# Altium schematic sheet IOT_POW_uc.SchDoc(IOT_POW_uc)
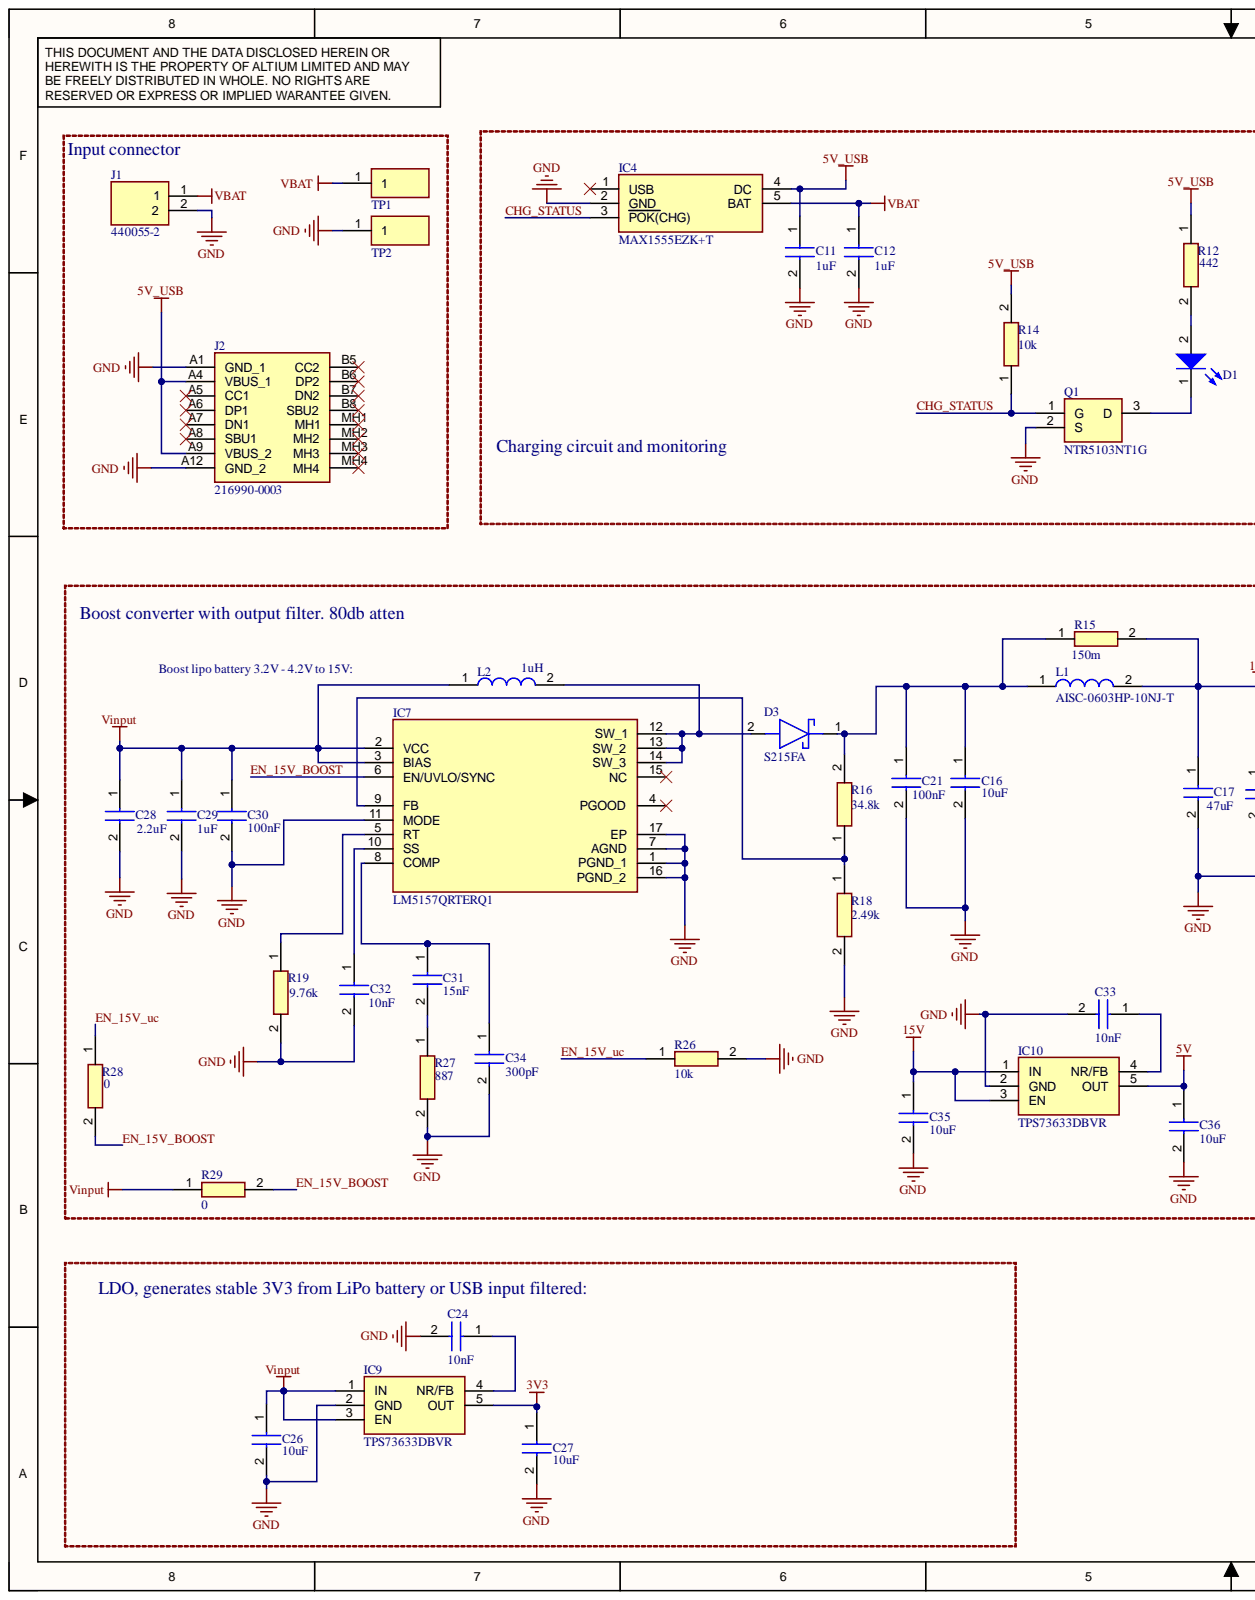
[diagram p0: IOT_POW_uc.SchDoc, left]
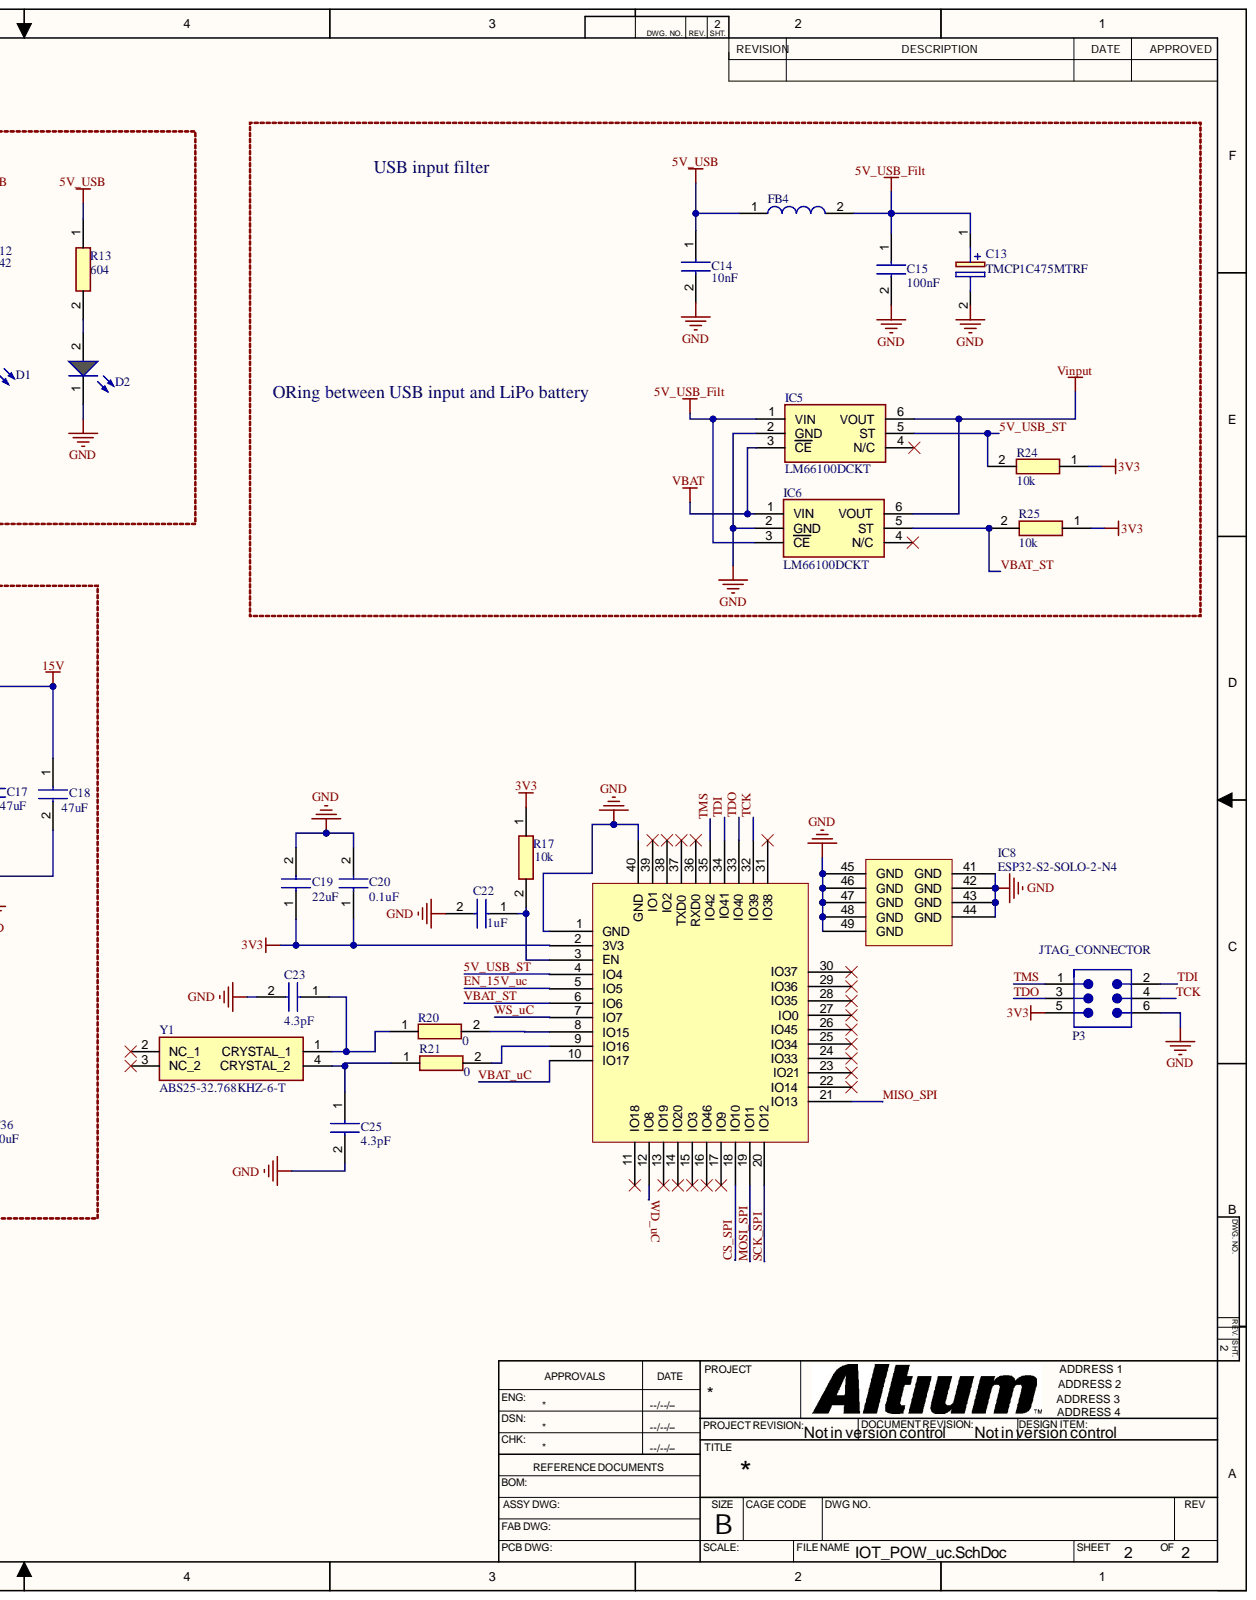
[diagram p1: IOT_POW_uc.SchDoc, right]

## Parts
C11  value 1uF  [CAP0603]  (hidden comment: CL10A105KO8NNND)
C12  value 1uF  [CAP0603]  (hidden comment: CL10A105KO8NNND)
C13  TMCP1C475MTRF  [CAPPM2012X120N]
C14  value 10nF  [CAP0603]  (hidden comment: C0603C103K4RACTU)
C15  value 100nF  [CAP0603]  (hidden comment: CL10B104KO8NNNC)
C16  value 10uF  [CAP0805]  (hidden comment: CL21A106KPFNNNF)
C17  value 47uF  [CAP0805]  (hidden comment: GRM21BR61A476ME15L)
C18  value 47uF  [CAP0805]  (hidden comment: GRM21BR61A476ME15L)
C19  value 22uF  [CAP0603]  (hidden comment: CL10A226MP8NUNE)
C20  value 0.1uF  [CAP0603]  (hidden comment: 0603YC104JAT4A)
C21  value 100nF  [CAP0603]  (hidden comment: MCASE168SB7104KTNA01)
C22  value 1uF  [CAP0603]  (hidden comment: CL10A105KO8NNND)
C23  value 4.3pF  [CAP0603]  (hidden comment: GRM1885C1H4R3CA01D)
C24  value 10nF  [CAP0603]  (hidden comment: C0603C103K4RACTU)
C25  value 4.3pF  [CAP0603]  (hidden comment: GRM1885C1H4R3CA01D)
C26  value 10uF  [CAP0805]  (hidden comment: CL21A106KPFNNNF)
C27  value 10uF  [CAP0805]  (hidden comment: CL21A106KPFNNNF)
C28  value 2.2uF  [CAP0805]  (hidden comment: CL21A225KOFNNNE)
C29  value 1uF  [CAP0603]  (hidden comment: CL10A105KO8NNND)
C30  value 100nF  [CAP0603]  (hidden comment: MCASE168SB7104KTNA01)
C31  value 15nF  [CAP0603]  (hidden comment: C0603C153G4GACTU)
C32  value 10nF  [CAP0603]  (hidden comment: C0603C103K4RACTU)
C33  value 10nF  [CAP0603]  (hidden comment: C0603C103K4RACTU)
C34  value 300pF  [CAP0603]  (hidden comment: 06033A301JAT2A)
C35  value 10uF  [CAP0805]  (hidden comment: CL21A106KPFNNNF)
C36  value 10uF  [CAP0805]  (hidden comment: CL21A106KPFNNNF)
D1  Blue, 3.2V, 140°  [WL-SMCW_0805_150080xx75000]  (value not drawn)
D2  Bright Green, 2V, 140°  [WL-SMCW_0805_150080xx75000]  (value not drawn)
D3  S215FA  [SODFL3618X143N]
FB4  BLM18PG330SN1D  [RESC0603]  (value not drawn)
IC4  MAX1555EZK+T  [SOT95P275X110-5N]
IC5  LM66100DCKT  [SOT65P210X110-6N]
IC6  LM66100DCKT  [SOT65P210X110-6N]
IC7  LM5157QRTERQ1  [QFN50P300X300X80-17N-D]
IC8  ESP32-S2-SOLO-2-N4  [ESP32-S2-SOLO-2-N4]
IC9  TPS73633DBVR  [SOT95P280X145-5N]
IC10  TPS73633DBVR  [SOT95P280X145-5N]
J1  440055-2  [SHDRRA2W50P0X200_1X2_600X770X525P]
J2  216990-0003  [2169900003]
L1  AISC-0603HP-10NJ-T  [RESC0603]
L2  value 1uH  [RESC0630]  (hidden comment: HM72L-06301R0LFTR)
P3  61300621121  [61300621121]  (value not drawn)
Q1  NTR5103NT1G  [SOT95P237X101-3N]
R12  value 442  [RESC0603]  (hidden comment: CRCW0603442RFKEA)
R13  value 604  [RESC0603]  (hidden comment: ERJ-3EKF6040V)
R14  value 10k  [RESC0603]  (hidden comment: CRCW060310K0JNEC)
R15  value 150m  [RESC0603]  (hidden comment: RL0603JR-070R15L)
R16  value 34.8k  [RESC0603]  (hidden comment: RMCF0603FT34K8)
R17  value 10k  [RESC0603]  (hidden comment: ESR03EZPJ103)
R18  value 2.49k  [RESC0603]  (hidden comment: CR0603-FX-2491ELF)
R19  value 9.76k  [RESC0603]  (hidden comment: CR0603-FX-9761ELF)
R20  value 0  [RESC0603]  (hidden comment: ERJ-3GEY0R00V)
R21  value 0  [RESC0603]  (hidden comment: ERJ-3GEY0R00V)
R24  value 10k  [RESC0603]  (hidden comment: CRCW060310K0JNEC)
R25  value 10k  [RESC0603]  (hidden comment: CRCW060310K0JNEC)
R26  value 10k  [RESC0603]  (hidden comment: CRCW060310K0JNEC)
R27  value 887  [RESC0603]  (hidden comment: RC0603FR-07887RL)
R28  value 0  [RESC0603]  (hidden comment: ERJ-3GEY0R00V)
R29  value 0  [RESC0603]  (hidden comment: ERJ-3GEY0R00V)
TP1  5006  [5006]  (value not drawn)
TP2  5006  [5006]  (value not drawn)
Y1  ABS25-32.768KHZ-6-T  [ABS2532768KHZT]

## Nets
3V3: C19.1 C20.1 C27.1 IC8.2(3V3) IC9.5(OUT) P3.5 R17.1 R24.1 R25.1
5V: C36.1 IC10.5(OUT)
5V_USB: C11.1 C14.1 FB4.1 IC4.4(DC) J2.A4(VBUS_1) J2.A9(VBUS_2) R12.1 R13.1 R14.2
5V_USB_Filt: C13.1 C15.1 FB4.2 IC5.1(VIN) IC6.3(CE)
15V: C17.1 C18.1 C35.1 IC10.1(IN) IC10.3(EN) L1.2 R15.2
GND: C11.2 C12.2 C13.2 C14.2 C15.2 C16.2 C17.2 C18.2 C19.2 C20.2 C21.2 C22.2 C23.2 C24.2 C25.2 C26.2 C27.2 C28.2 C29.2 C30.2 C32.2 C33.2 C34.2 C35.2 C36.2 D2.1 IC4.2(GND) IC5.2(GND) IC6.2(GND) IC7.1(PGND_1) IC7.7(AGND) IC7.11(MODE) IC7.16(PGND_2) IC7.17(EP) IC8.1(GND) IC8.40(GND) IC8.41(GND) IC8.42(GND) IC8.43(GND) IC8.44(GND) IC8.45 IC8.46 IC8.47 IC8.48 IC8.49 IC9.2(GND) IC10.2(GND) J1.2 J2.A1(GND_1) J2.A12(GND_2) P3.6 Q1.2 R18.2 R19.2 R26.2 R27.2 TP2.1
VBAT: C12.1 IC4.5(BAT) IC5.3(CE) IC6.1(VIN) J1.1 TP1.1
Vinput: C26.1 C28.1 C29.1 C30.1 IC5.6(VOUT) IC6.6(VOUT) IC7.2(VCC) IC7.3(BIAS) IC9.1(IN) IC9.3(EN) L2.1 R29.1
5V_USB_ST: IC5.5(ST) IC8.4(IO4) R24.2
CHG_STATUS: IC4.3(POK(CHG)) Q1.1 R14.1
CS_SPI: IC8.18(IO10)
EN_15V_BOOST: IC7.6(EN/UVLO/SYNC) R28.2 R29.2
EN_15V_uc: IC8.5(IO5) R26.1 R28.1
MISO_SPI: IC8.21(IO13)
MOSI_SPI: IC8.19(IO11)
SCK_SPI: IC8.20(IO12)
TCK: IC8.32(IO39) P3.4
TDI: IC8.34(IO41) P3.2
TDO: IC8.33(IO40) P3.3
TMS: IC8.35(IO42) P3.1
VBAT_ST: IC6.5(ST) IC8.6(IO6) R25.2
VBAT_uC: IC8.10(IO17)
WD_uC: IC8.12(IO8)
WS_uC: IC8.7(IO7)
NetC16_1: C16.1 C21.1 D3.1 L1.1 R15.1 R16.2
NetC22_1: C22.1 IC8.3(EN) R17.2
NetC23_1: C23.1 R20.1 Y1.1(CRYSTAL_1)
NetC24_1: C24.1 IC9.4(NR/FB)
NetC25_1: C25.1 R21.1 Y1.4(CRYSTAL_2)
NetC31_1: C31.1 C34.1 IC7.8(COMP)
NetC31_2: C31.2 R27.1
NetC32_1: C32.1 IC7.10(SS)
NetC33_1: C33.1 IC10.4(NR/FB)
NetD1_1: D1.1 Q1.3
NetD1_2: D1.2 R12.2
NetD2_2: D2.2 R13.2
NetD3_2: D3.2 IC7.12(SW_1) IC7.13(SW_2) IC7.14(SW_3) L2.2
NetIC7_5: IC7.5(RT) R19.1
NetIC7_9: IC7.9(FB) R16.1 R18.1
NetIC8_8: IC8.8(IO15) R20.2
NetIC8_9: IC8.9(IO16) R21.2

Not connected: IC4 1(USB); IC5 4(N/C); IC6 4(N/C); IC7 4(PGOOD) 15(NC); IC8 11(IO18) 13(IO19) 14(IO20) 15(IO3) 16(IO46) 17(IO9) 22(IO14) 23(IO21) 24(IO33) 25(IO34) 26(IO45) 27(IO0) 28(IO35) 29(IO36) 30(IO37) 31(IO38) 36(RXD0) 37(TXD0) 38(IO2) 39(IO1); J2 A5(CC1) A6(DP1) A7(DN1) A8(SBU1) B5(CC2) B6(DP2) B7(DN2) B8(SBU2) MH1 MH2 MH3 MH4; Y1 2(NC_1) 3(NC_2)

## Library data of the parts
C11 C12 C22 C29 = CL10A105KO8NNND: Multilayer Ceramic Capacitors MLCC - SMD/SMT 1uF+/-10% 16V X5R 16 0603; Manufacturer_Name: Samsung Electro-Mechanics; Case: 0603; Component Kind: Standard; Datasheet Link: https://product.tdk.com/info/en/catalog/datasheets/mlcc_commercial_midvoltage_en.pdf; Height: 0.8mm; Library Name: Library_JS.IntLib; Manufacturer_Part_Number: CL10A105KO8NNND
C13 = TMCP1C475MTRF: Tantalum Capacitors - Solid SMD 16volts 4.7uF 20% Molded Case, 0805; Manufacturer_Name: Vishay; Case: 0805; Component Kind: Standard; Datasheet Link: https://www.vishay.com/docs/40179/tmcp.pdf; Height: 1.2mm; Library Name: Library_JS.IntLib; Manufacturer_Part_Number: TMCP1C475MTRF; Value: 4.7uF
C14 C24 C32 C33 = C0603C103K4RACTU: Multilayer Ceramic Capacitors MLCC - SMD/SMT 16V 0.01uF X7R 0603 10%; Manufacturer_Name: KEMET; Case: 0603; Component Kind: Standard; Datasheet Link: https://product.tdk.com/info/en/catalog/datasheets/mlcc_commercial_midvoltage_en.pdf; Height: 0.8mm; Library Name: Library_JS.IntLib; Manufacturer_Part_Number: C0603C103K4RACTU
C15 = CL10B104KO8NNNC: Multilayer Ceramic Capacitors MLCC - SMD/SMT 100nF+/-10% 16V X7R 1608; Manufacturer_Name: Samsung Electro-Mechanics; Case: 0603; Component Kind: Standard; Datasheet Link: https://product.tdk.com/info/en/catalog/datasheets/mlcc_commercial_midvoltage_en.pdf; Height: 0.8mm; Library Name: Library_JS.IntLib; Manufacturer_Part_Number: CL10B104KO8NNNC
C16 C26 C27 C35 C36 = CL21A106KPFNNNF: Multilayer Ceramic Capacitors MLCC - SMD/SMT 10uF+/-10% 10V X5R 2 0805; Manufacturer_Name: Samsung Electro-Mechanics; Tolerance: 10%; Case: 0805; Component Kind: Standard; Datasheet Link: https://connect.kemet.com:7667/gateway/IntelliData-ComponentDocumentation/1.0/download/specsheet/C0805C104M5RACTU; Dielectric: X5R; Height: 0.88mm; Library Name: Library_JS.IntLib; Manufacturer_Part_Number: CL21A106KPFNNNF
C17 C18 = GRM21BR61A476ME15L: Multilayer Ceramic Capacitors MLCC - SMD/SMT 47 uF 10 VDC 20% 0805 X5R; Manufacturer_Name: Murata Electronics; Case: 0805; Component Kind: Standard; Datasheet Link: https://connect.kemet.com:7667/gateway/IntelliData-ComponentDocumentation/1.0/download/specsheet/C0805C104M5RACTU; Height: 0.88mm; Library Name: Library_JS.IntLib; Manufacturer_Part_Number: GRM21BR61A476ME15L
C19 = CL10A226MP8NUNE: Multilayer Ceramic Capacitors MLCC - SMD/SMT 22uF+/-20% 10V X5R 1 0603; Manufacturer_Name: Samsung Electro-Mechanics; Case: 0603; Component Kind: Standard; Height: 0.8mm; Library Name: Library_JS.IntLib; Manufacturer_Part_Number: CL10A226MP8NUNE
C20 = 0603YC104JAT4A: Multilayer Ceramic Capacitors MLCC - SMD/SMT  16V 0.1uF; Manufacturer_Name: KYOCERA AVX; Case: 0603; Component Kind: Standard; Datasheet Link: https://product.tdk.com/info/en/catalog/datasheets/mlcc_commercial_midvoltage_en.pdf; Height: 0.8mm; Library Name: Library_JS.IntLib; Manufacturer_Part_Number: 0603YC104JAT4A
C21 C30 = MCASE168SB7104KTNA01: Multilayer Ceramic Capacitors MLCC - SMD/SMT 16V 0.1uF X7R 0603 10 %; Manufacturer_Name: TAIYO YUDEN; Case: 0603; Component Kind: Standard; Datasheet Link: https://product.tdk.com/info/en/catalog/datasheets/mlcc_commercial_midvoltage_en.pdf; Height: 0.8mm; Library Name: Library_JS.IntLib; Manufacturer_Part_Number: MCASE168SB7104KTNA01
C23 C25 = GRM1885C1H4R3CA01D: Multilayer Ceramic Capacitors MLCC - SMD/SMT 4.3 pF 50 VDC 0.25 pF 0603 C0G (NP0); Manufacturer_Name: Murata Electronics; Case: 0603; Component Kind: Standard; Datasheet Link: https://product.tdk.com/info/en/catalog/datasheets/mlcc_commercial_midvoltage_en.pdf; Height: 0.8mm; Library Name: Library_JS.IntLib; Manufacturer_Part_Number: GRM1885C1H4R3CA01D
C28 = CL21A225KOFNNNE: Multilayer Ceramic Capacitors MLCC - SMD/SMT 2.2uF+/-10% 16V X5R 0805; Manufacturer_Name: Samsung Electro-Mechanics; Case: 0805; Component Kind: Standard; Datasheet Link: https://connect.kemet.com:7667/gateway/IntelliData-ComponentDocumentation/1.0/download/specsheet/C0805C104M5RACTU; Height: 0.88mm; Library Name: Library_JS.IntLib; Manufacturer_Part_Number: CL21A225KOFNNNE
C31 = C0603C153G4GACTU: Multilayer Ceramic Capacitors MLCC - SMD/SMT 16V 0.015uF C0G 0603 2%; Manufacturer_Name: KEMET; Case: 0603; Component Kind: Standard; Datasheet Link: https://product.tdk.com/info/en/catalog/datasheets/mlcc_commercial_midvoltage_en.pdf; Height: 0.8mm; Library Name: Library_JS.IntLib; Manufacturer_Part_Number: C0603C153G4GACTU
C34 = 06033A301JAT2A: Multilayer Ceramic Capacitors MLCC - SMD/SMT 25V 300pF C0G 0603 5 % Tol; Manufacturer_Name: KYOCERA AVX; Case: 0603; Component Kind: Standard; Datasheet Link: https://product.tdk.com/info/en/catalog/datasheets/mlcc_commercial_midvoltage_en.pdf; Height: 0.8mm; Library Name: Library_JS.IntLib; Manufacturer_Part_Number: 06033A301JAT2A
D1 = 150080BS75000: WL-SMCW Mono-color Chip LED Waterclear, size 0805, Blue, 3.2V, 140°; Manufacturer: Wurth Elektronik; Case/Size Code: 0805; Chip Technology: InGaN; Dominant Wavelength: 470nm; Luminous Intensity: 145mcd; Peak Wavelength: 465nm; Category: Signal LEDs; Component Kind: Standard; ComponentLink1Description: Website Link; ComponentLink1URL: https://www.we-online.com/redexpert/article/150080BS75000?ad; ComponentLink2Description: Datasheet Link; ComponentLink2URL: https://www.we-online.com/redexpert/spec/150080BS75000?ad; Emitting Color: Blue; Forward Voltage: 3.2V; Height: 0.7mm; Length: 2mm; Library Name: Altium_WL-SMCW (rev22d).IntLib; Manufacturer Part Number: 150080BS75000; Match Code: WL-SMCW; Mount: Surface Mount; Operating Temperature Max: 85°C; Operating Temperature Min: -40°C; Packaging: Tape and Reel; Simulation: 0805_150080BS75000; Viewing Angle: 140°; Width: 1.25mm
D2 = 150080VS75000: WL-SMCW Mono-color Chip LED Waterclear, size 0805, Bright Green, 2V, 140°; Manufacturer: Wurth Elektronik; Case/Size Code: 0805; Chip Technology: AlInGaP; Dominant Wavelength: 570nm; Luminous Intensity: 40mcd; Peak Wavelength: 572nm; Category: Signal LEDs; Component Kind: Standard; ComponentLink1Description: Website Link; ComponentLink1URL: https://www.we-online.com/redexpert/article/150080VS75000?ad; ComponentLink2Description: Datasheet Link; ComponentLink2URL: https://www.we-online.com/redexpert/spec/150080VS75000?ad; Emitting Color: Bright Green; Forward Voltage: 2V; Height: 0.7mm; Length: 2mm; Library Name: Altium_WL-SMCW (rev22d).IntLib; Manufacturer Part Number: 150080VS75000; Match Code: WL-SMCW; Mount: Surface Mount; Operating Temperature Max: 85°C; Operating Temperature Min: -40°C; Packaging: Tape and Reel; Simulation: 0805_150080VS75000; Viewing Angle: 140°; Width: 1.25mm
D3 = S215FA: Schottky Diodes & Rectifiers 150V 2A Schottky Barrier Rectifier; Manufacturer_Name: onsemi; Arrow Part Number: S215FA; Arrow Price/Stock: https://www.arrow.com/en/products/s215fa/on-semiconductor; Component Kind: Standard; Datasheet Link: https://componentsearchengine.com/Datasheets/2/S215FA.pdf; Height: 1.43mm; Library Name: Library_JS.IntLib; Manufacturer_Part_Number: S215FA; Mouser Part Number: 512-S215FA; Mouser Price/Stock: https://www.mouser.com/Search/Refine.aspx?Keyword=512-S215FA
FB4 = BLM18PG330SN1D: Ferrite Beads 33 OHM 25% 3A; Manufacturer_Name: Murata Electronics; Value: 33Ohm; Case: 0603; Component Kind: Standard; Datasheet Link: http://www.bourns.com/docs/Product-Datasheets/CE201210.pdf; Height: 1.03mm; Library Name: Library_JS.IntLib; Manufacturer_Part_Number: BLM18PG330SN1D
IC4 = MAX1555EZK+T: Battery Management SOT23, Dual-Input, USB/AC Adapter, 1-Cel; Manufacturer_Name: Analog Devices; Component Kind: Standard; Datasheet Link: http://datasheets.maximintegrated.com/en/ds/MAX1551-MAX1555.pdf; Height: 1.1mm; Library Name: Library_JS.IntLib; Manufacturer_Part_Number: MAX1555EZK+T
IC5 IC6 = LM66100DCKT: Hot Swap Voltage Controllers 1.5-V to 5.5-V, 1.5-A, 0.5-uA IQ ideal diode with integrated FET 6-SC70 -40 to 10; Manufacturer_Name: Texas Instruments; Component Kind: Standard; Datasheet Link: http://www.ti.com/general/docs/suppproductinfo.tsp?distId=10&gotoUrl=http%3A%2F%2Fwww.ti.com%2Flit%2Fgpn%2flm66100; Height: 1.1mm; Library Name: Library_JS.IntLib; Manufacturer_Part_Number: LM66100DCKT
IC7 = LM5157QRTERQ1: Switching Voltage Regulators 6-A, 50-V, 2.2-MHz wide VIN boost, flyback, & SEPIC converter with dual random spread spectrum 16-WQFN -40 to 150; Manufacturer_Name: Texas Instruments; Case: WQFN-16; Component Kind: Standard; Datasheet Link: https://pdf1.alldatasheet.com/datasheet-pdf/view/1264204/TI/LM5157QRTERQ1.html; Height: 0.8mm; Library Name: Library_JS.IntLib; Manufacturer_Part_Number: LM5157QRTERQ1
IC8 = ESP32-S2-SOLO-2-N4: WiFi Modules - 802.11 SMD module, ESP32-S2 ECO1, 4 MB SPI flash, PCB antenna; Manufacturer: Espressif Systems; Component Kind: Standard; Library Name: Library_JS.IntLib; Manufacturer Part Number: ESP32-S2-SOLO-2-N4
IC9 IC10 = TPS73633DBVR: LDO Voltage Regulators Cap-Free NMOS 400mA; Manufacturer_Name: Texas Instruments; Vin: 1.7V - 5.5V; Component Kind: Standard; Datasheet Link: http://www.ti.com/lit/gpn/tps736; Height: 1.45mm; Library Name: Library_JS.IntLib; Manufacturer_Part_Number: TPS73633DBVR
J1 = 440055-2: Headers & Wire Housings 2.0mm HDR 2pos R/A HPI for JST 2.0 mmconnector; Manufacturer_Name: TE Connectivity; Number of Positions: 2; Pitch: 2mm; Component Kind: Standard; Datasheet Link: https://www.te.com/commerce/DocumentDelivery/DDEController?Action=srchrtrv&DocNm=1-1773713-2_HPI_QRG_EN&DocType=Data%20Sheet&DocLang=English&PartCntxt=440055-2&DocFormat=pdf; Height: 5.25mm; Library Name: Library_JS.IntLib; Manufacturer_Part_Number: 440055-2
J2 = 216990-0003: Manufacturer_Name: Molex; Component Kind: Standard; Datasheet Link: https://www.molex.com/pdm_docs/sd/2169900003_sd.pdf; Description: 216990-0003; Height: 3.36mm; Library Name: Library_JS.IntLib; Manufacturer_Part_Number: 216990-0003
L1 = AISC-0603HP-10NJ-T: Power Inductors - SMD FIXED IND 10NH 1.35A 60 MOHM SMD; Manufacturer_Name: ABRACON; Case: 0603; Component Kind: Standard; Datasheet Link: http://www.bourns.com/docs/Product-Datasheets/CE201210.pdf; Height: 1.03mm; Library Name: Library_JS.IntLib; Manufacturer_Part_Number: AISC-0603HP-10NJ-T; Value: 10nH
L2 = HM72L-06301R0LFTR: Power Inductors - SMD 1uH +/-20% @11A; Manufacturer_Name: ABRACON; Manufacturer_Part_Number: AISC-0603HP-10NJ-T; Case: 0630; Component Kind: Standard; Datasheet Link: http://www.bourns.com/docs/Product-Datasheets/CE201210.pdf; Height: 1.03mm; Library Name: Library_JS.IntLib
P3 = 61300621121: WR-PHD Pin Header, THT, Vertical, pitch 2.54mm, 2 Row, 6P; Manufacturer: Wurth Elektronik; Contact Resistance: 20mOhm; Rated Current: 3A; Withstanding Voltage: 500V; Working Voltage: 250V; Category: Board-to-Board Connectors; Component Kind: Standard; ComponentLink1Description: Website Link; ComponentLink1URL: https://www.we-online.com/redexpert/article/61300621121?ad; ComponentLink2Description: Datasheet Link; ComponentLink2URL: https://www.we-online.com/redexpert/spec/61300621121?ad; Gender: Pin Header; Library Name: Altium_WR-PHD (rev24a).IntLib; Manufacturer Part Number: 61300621121; Match Code: WR-PHD; Mount: Through Hole; Number of Pins: 6; Number of Rows: 2; Operating Temperature Max: 105°C; Operating Temperature Min: -40°C; Orientation: Vertical; Packaging: Bag; Pitch: 2.54mm
Q1 = NTR5103NT1G: MOSFETs NFET SOT23 60V 310MA 2.5; Manufacturer_Name: onsemi; Component Kind: Standard; Datasheet Link: https://componentsearchengine.com/Datasheets/1/NTR5103NT1G.pdf; Height: 1.01mm; Library Name: Library_JS.IntLib; Manufacturer_Part_Number: NTR5103NT1G
R12 = CRCW0603442RFKEA: Thick Film Resistors - SMD 1/10watt 442ohms 1%; Manufacturer_Name: Vishay / Dale; Case: 0603; Component Kind: Standard; Height: 0.55mm; Library Name: Library_JS.IntLib; Manufacturer_Part_Number: CRCW0603442RFKEA
R13 = ERJ-3EKF6040V: Thick Film Resistors - SMD 0603 604ohms 1% AEC-Q200; Manufacturer_Name: Panasonic; Case: 0603; Component Kind: Standard; Height: 0.55mm; Library Name: Library_JS.IntLib; Manufacturer_Part_Number: ERJ-3EKF6040V
R14 R24-R26 = CRCW060310K0JNEC: Thick Film Resistors - SMD 10K OHM 5%; Manufacturer_Name: Vishay / Dale; Case: 0603; Component Kind: Standard; Height: 0.55mm; Library Name: Library_JS.IntLib; Manufacturer_Part_Number: CRCW060310K0JNEC
R15 = RL0603JR-070R15L: Thick Film Resistors - SMD 0603 604ohms 1% AEC-Q200; Manufacturer_Name: YAGEO; Case: 0603; Component Kind: Standard; Height: 0.55mm; Library Name: Library_JS.IntLib; Manufacturer_Part_Number: RL0603JR-070R15L
R16 = RMCF0603FT34K8: Thick Film Resistors - SMD 34.8KOhms 0603 0.1W 1% Std Power AEC-Q200; Manufacturer_Name: SEI Stackpole; Case: 0603; Component Kind: Standard; Height: 0.55mm; Library Name: Library_JS.IntLib; Manufacturer_Part_Number: RMCF0603FT34K8
R17 = ESR03EZPJ103: Thick Film Resistors - SMD 0603 10Kohm 5% Anti Surge AEC-Q200; Manufacturer_Name: ROHM Semiconductor; Case: 0603; Component Kind: Standard; Height: 0.55mm; Library Name: Library_JS.IntLib; Manufacturer_Part_Number: ESR03EZPJ103
R18 = CR0603-FX-2491ELF: Thick Film Resistors - SMD 2.49K ohm 1%; Manufacturer_Name: Bourns; Case: 0603; Component Kind: Standard; Height: 0.55mm; Library Name: Library_JS.IntLib; Manufacturer_Part_Number: CR0603-FX-2491ELF
R19 = CR0603-FX-9761ELF: Thick Film Resistors - SMD 9.76K ohm 1%; Manufacturer_Name: Bourns; Case: 0603; Component Kind: Standard; Height: 0.55mm; Library Name: Library_JS.IntLib; Manufacturer_Part_Number: CR0603-FX-9761ELF
R20 R21 R28 R29 = ERJ-3GEY0R00V: Thick Film Resistors 0603 Zero Ohms; Manufacturer_Name: Panasonic; Case: 0603; Component Kind: Standard; Height: 0.55mm; Library Name: Library_JS.IntLib; Manufacturer_Part_Number: ERJ-3GEY0R00V
R27 = RC0603FR-07887RL: Thick Film Resistors - SMD 887 Ohms 100 mW 0603 1%; Manufacturer_Name: YAGEO; Case: 0603; Component Kind: Standard; Height: 0.55mm; Library Name: Library_JS.IntLib; Manufacturer_Part_Number: RC0603FR-07887RL
TP1 TP2 = 5006: Test Point; Manufacturer_Name: Keystone Electronics; Component Kind: Standard; Datasheet Link: https://www.keyelco.com/product-pdf.cfm?p=1315; Height: 5.72mm; Library Name: Library_JS.IntLib; Manufacturer_Part_Number: 5006
Y1 = ABS25-32.768KHZ-6-T: Crystals 32.768 KHZ 6.0PF -40+85C; Manufacturer_Name: ABRACON; Component Kind: Standard; Datasheet Link: https://abracon.com/Resonators/abs25.pdf; Height: 2mm; Library Name: Library_JS.IntLib; Manufacturer_Part_Number: ABS25-32.768KHZ-6-T
